# Altium schematic sheet MotherBoard_Interconnect.SchDoc
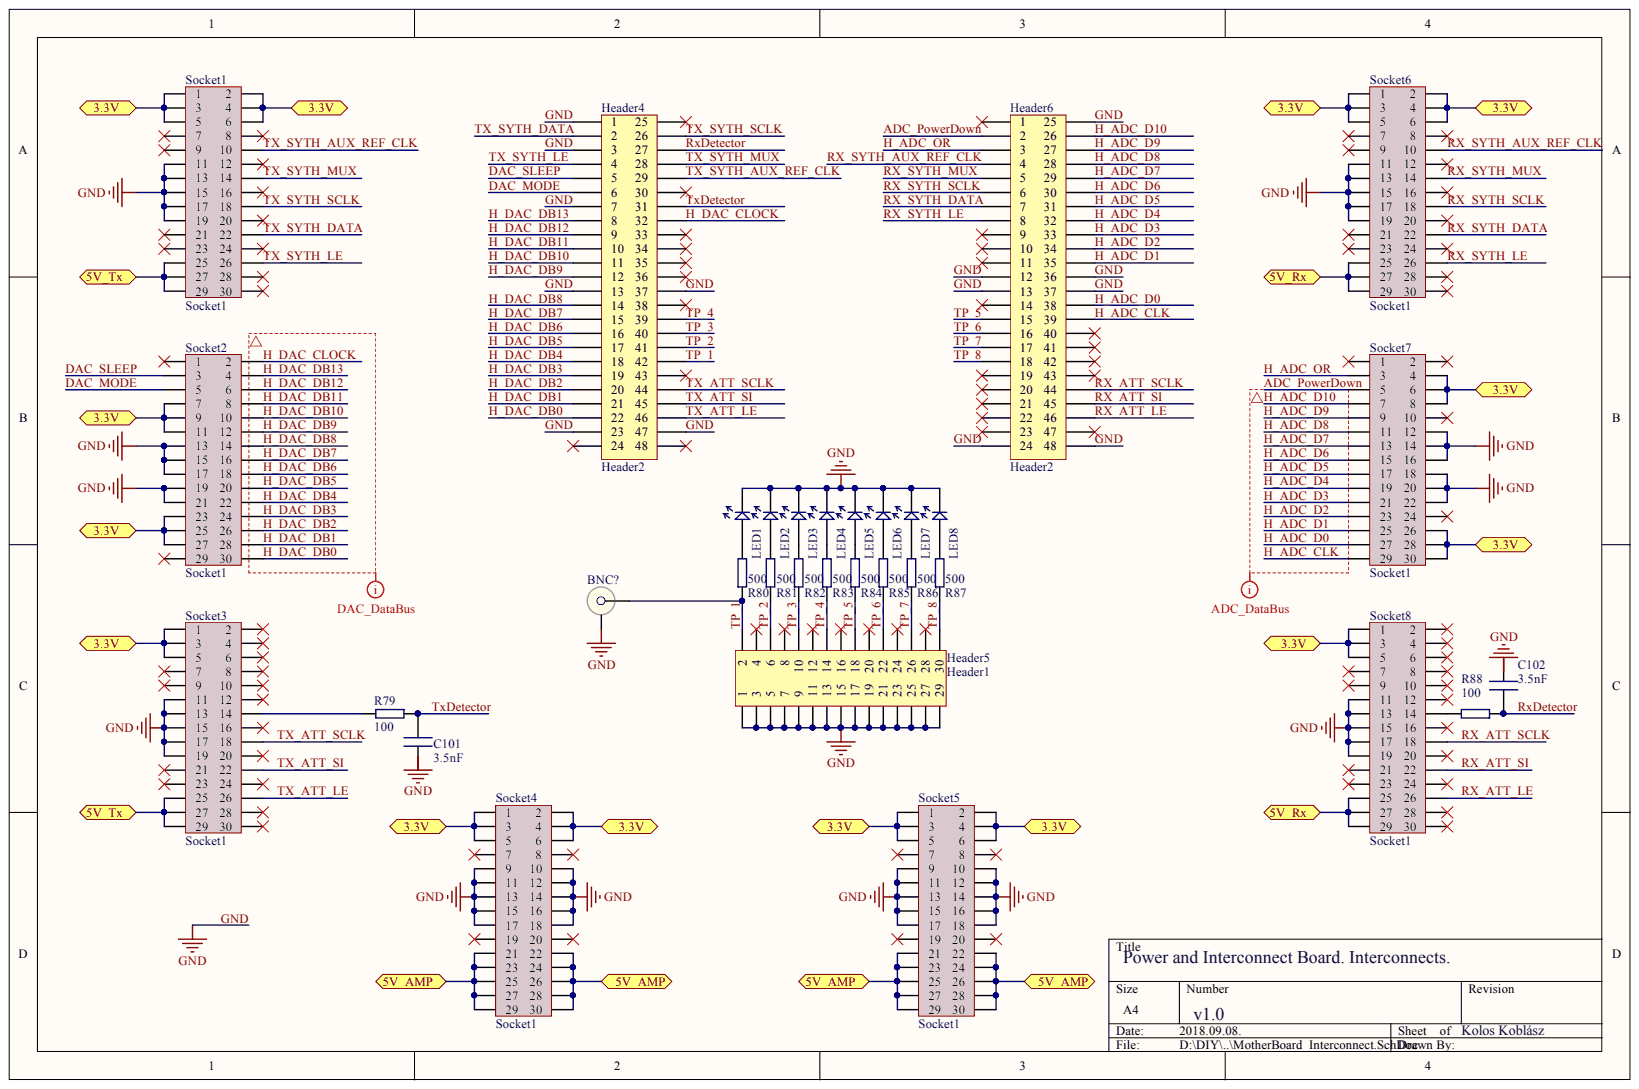
[diagram p0: MotherBoard_Interconnect.SchDoc]

## Parts
BNC?  BNC1  (value not drawn)
C101  value 3.5nF  (hidden comment: Cap1)
C102  value 3.5nF  (hidden comment: Cap1)
Header4  Header2  [Header2]
Header5  Header1  [Header1]
Header6  Header2  [Header2]
LED1  LED_Green  [0805]  (value not drawn)
LED2  LED_Green  [0805]  (value not drawn)
LED3  LED_Green  [0805]  (value not drawn)
LED4  LED_Green  [0805]  (value not drawn)
LED5  LED_Green  [0805]  (value not drawn)
LED6  LED_Green  [0805]  (value not drawn)
LED7  LED_Green  [0805]  (value not drawn)
LED8  LED_Green  [0805]  (value not drawn)
R79  value 100  [SMD]  (hidden comment: Resistor)
R80  value 500  [SMD]  (hidden comment: Resistor)
R81  value 500  [SMD]  (hidden comment: Resistor)
R82  value 500  [SMD]  (hidden comment: Resistor)
R83  value 500  [SMD]  (hidden comment: Resistor)
R84  value 500  [SMD]  (hidden comment: Resistor)
R85  value 500  [SMD]  (hidden comment: Resistor)
R86  value 500  [SMD]  (hidden comment: Resistor)
R87  value 500  [SMD]  (hidden comment: Resistor)
R88  value 100  [SMD]  (hidden comment: Resistor)
Socket1  Socket1  [Socket1]
Socket2  Socket1  [Socket1]
Socket3  Socket1  [Socket1]
Socket4  Socket1  [Socket1]
Socket5  Socket1  [Socket1]
Socket6  Socket1  [Socket1]
Socket7  Socket1  [Socket1]
Socket8  Socket1  [Socket1]

## Ports
3.3V, 5V_AMP, 5V_RX, 5V_TX

## Nets
3.3V: Socket1.1 Socket1.2 Socket1.3 Socket1.4 Socket1.5 Socket1.6 Socket2.7 Socket2.9 Socket2.11 Socket2.23 Socket2.25 Socket2.27 Socket3.1 Socket3.3 Socket3.5 Socket4.1 Socket4.2 Socket4.3 Socket4.4 Socket4.5 Socket4.6 Socket5.1 Socket5.2 Socket5.3 Socket5.4 Socket5.5 Socket5.6 Socket6.1 Socket6.2 Socket6.3 Socket6.4 Socket6.5 Socket6.6 Socket7.4 Socket7.6 Socket7.8 Socket7.26 Socket7.28 Socket7.30 Socket8.1 Socket8.3 Socket8.5 ; port 3.3V
5V_AMP: Socket4.21 Socket4.22 Socket4.23 Socket4.24 Socket4.25 Socket4.26 Socket4.27 Socket4.28 Socket4.29 Socket4.30 Socket5.21 Socket5.22 Socket5.23 Socket5.24 Socket5.25 Socket5.26 Socket5.27 Socket5.28 Socket5.29 Socket5.30 ; port 5V_AMP
ADC_POWERDOWN: Header6.2 Socket7.5
DAC_MODE: Header4.6 Socket2.5
DAC_SLEEP: Header4.5 Socket2.3
GND: BNC?.2 Header4.1 Header4.3 Header4.7 Header4.13 Header4.23 Header4.37 Header4.47 Header5.1 Header5.3 Header5.5 Header5.7 Header5.9 Header5.11 Header5.13 Header5.15 Header5.17 Header5.19 Header5.21 Header5.23 Header5.25 Header5.27 Header5.29 Header6.12 Header6.13 Header6.24 Header6.25 Header6.36 Header6.37 Header6.48 LED1.1 LED2.1 LED3.1 LED4.1 LED5.1 LED6.1 LED7.1 LED8.1 Socket1.11 Socket1.13 Socket1.15 Socket1.17 Socket1.19 Socket2.13 Socket2.15 Socket2.17 Socket2.19 Socket2.21 Socket3.11 Socket3.13 Socket3.15 Socket3.17 Socket3.19 Socket4.9 Socket4.10 Socket4.11 Socket4.12 Socket4.13 Socket4.14 Socket4.15 Socket4.16 Socket4.17 Socket4.18 Socket5.9 Socket5.10 Socket5.11 Socket5.12 Socket5.13 Socket5.14 Socket5.15 Socket5.16 Socket5.17 Socket5.18 Socket6.11 Socket6.13 Socket6.15 Socket6.17 Socket6.19 Socket7.12 Socket7.14 Socket7.16 Socket7.18 Socket7.20 Socket7.22 Socket8.11 Socket8.13 Socket8.15 Socket8.17 Socket8.19
H_ADC_CLK: Header6.39 Socket7.29
H_ADC_D0: Header6.38 Socket7.27
H_ADC_D1: Header6.35 Socket7.25
H_ADC_D2: Header6.34 Socket7.23
H_ADC_D3: Header6.33 Socket7.21
H_ADC_D4: Header6.32 Socket7.19
H_ADC_D5: Header6.31 Socket7.17
H_ADC_D6: Header6.30 Socket7.15
H_ADC_D7: Header6.29 Socket7.13
H_ADC_D8: Header6.28 Socket7.11
H_ADC_D9: Header6.27 Socket7.9
H_ADC_D10: Header6.26 Socket7.7
H_ADC_OR: Header6.3 Socket7.3
H_DAC_CLOCK: Header4.32 Socket2.2
H_DAC_DB0: Header4.22 Socket2.30
H_DAC_DB1: Header4.21 Socket2.28
H_DAC_DB2: Header4.20 Socket2.26
H_DAC_DB3: Header4.19 Socket2.24
H_DAC_DB4: Header4.18 Socket2.22
H_DAC_DB5: Header4.17 Socket2.20
H_DAC_DB6: Header4.16 Socket2.18
H_DAC_DB7: Header4.15 Socket2.16
H_DAC_DB8: Header4.14 Socket2.14
H_DAC_DB9: Header4.12 Socket2.12
H_DAC_DB10: Header4.11 Socket2.10
H_DAC_DB11: Header4.10 Socket2.8
H_DAC_DB12: Header4.9 Socket2.6
H_DAC_DB13: Header4.8 Socket2.4
RX_ATT_LE: Header6.46 Socket8.26
RX_ATT_SCLK: Header6.44 Socket8.18
RX_ATT_SI: Header6.45 Socket8.22
RX_SYTH_AUX_REF_CLK: Header6.4 Socket6.10
RX_SYTH_DATA: Header6.7 Socket6.22
RX_SYTH_LE: Header6.8 Socket6.26
RX_SYTH_MUX: Header6.5 Socket6.14
RX_SYTH_SCLK: Header6.6 Socket6.18
RXDETECTOR: C102.1 Header4.27 R88.1
TP_1: BNC?.1 Header4.42 Header5.2 R80.1
TP_2: Header4.41 Header5.6 R81.1
TP_3: Header4.40 Header5.10 R82.1
TP_4: Header4.39 Header5.14 R83.1
TP_5: Header5.18 Header6.15 R84.1
TP_6: Header5.22 Header6.16 R85.1
TP_7: Header5.26 Header6.17 R86.1
TP_8: Header5.30 Header6.18 R87.1
TX_ATT_LE: Header4.46 Socket3.26
TX_ATT_SCLK: Header4.44 Socket3.18
TX_ATT_SI: Header4.45 Socket3.22
TX_SYTH_AUX_REF_CLK: Header4.29 Socket1.10
TX_SYTH_DATA: Header4.2 Socket1.22
TX_SYTH_LE: Header4.4 Socket1.26
TX_SYTH_MUX: Header4.28 Socket1.14
TX_SYTH_SCLK: Header4.26 Socket1.18
TXDETECTOR: C101.1 Header4.31 R79.1
NetLED1_2: LED1.2 R80.2
NetLED2_2: LED2.2 R81.2
NetLED3_2: LED3.2 R82.2
NetLED4_2: LED4.2 R83.2
NetLED5_2: LED5.2 R84.2
NetLED6_2: LED6.2 R85.2
NetLED7_2: LED7.2 R86.2
NetLED8_2: LED8.2 R87.2
NetR79_2: R79.2 Socket3.14
NetR88_2: R88.2 Socket8.14
NetSocket1_25: Socket1.25 Socket1.27 Socket1.29 Socket3.25 Socket3.27 Socket3.29 ; port 5V_TX
NetSocket6_25: Socket6.25 Socket6.27 Socket6.29 Socket8.25 Socket8.27 Socket8.29 ; port 5V_RX
N00125:
N00126:

Not connected: C101 2; C102 2; Header4 24 25 30 33 34 35 36 38 43 48; Header5 4 8 12 16 20 24 28; Header6 1 9 10 11 14 19 20 21 22 23 40 41 42 43 47; Socket1 7 8 9 12 16 20 21 23 24 28 30; Socket2 1 29; Socket3 2 4 6 7 8 9 10 12 16 20 21 23 24 28 30; Socket4 7 8 19 20; Socket5 7 8 19 20; Socket6 7 8 9 12 16 20 21 23 24 28 30; Socket7 1 2 10 24; Socket8 2 4 6 7 8 9 10 12 16 20 21 23 24 28 30

## Library data of the parts
BNC? = BNC1: BNC Female; Shape: Straight; Component Kind: Standard; Library Name: RadioProjectSchLib.SchLib; Mounting: TroughHole
C101 C102 = Cap1: SMD Capacitor; MaxVolt: 16V; Precision: 1%; Component Kind: Standard; Library Name: RadioProjectSchLib.SchLib
Header4 Header6 = Header2: 48 Pin Header; PinNumber: 48; Pitch: 2.54mm; Row: 2; Component Kind: Standard; Digi Key Order Code: 3M156386-48-ND; Library Name: RadioProjectSchLib.SchLib; Type: 180°
Header5 = Header1: 29 Pin Header; Manufacterer: Samtec; PartNumber: TLW-115-01-G-D-002-RA; PinNumber: 29; Pitch: 2.54mm; Row: 2; Component Kind: Standard; Library Name: RadioProjectSchLib.SchLib; Type: 90°
LED1-LED8 = LED_Green: Diode; Manufacturer: LITEON; Partnumber: LTST-C171KGKT; ForwardCurrent: 20mA; ForwardVoltage: 2V; MaxForwardCurrent: 30mA; MaxRevVoltage: 5V; OrderCode: 160-1426-1-ND; Type: Green; Component Kind: Standard; Library Name: RadioProjectSchLib.SchLib
R79-R88 = Res1: SMD Resistor; MaxPower: 0.1W; Precision: 1%; Component Kind: Standard; Library Name: RadioProjectSchLib.SchLib
Socket1-Socket8 = Socket1: 30 Pin Socket; Manufacterer: Samtec; PartNumber: SLW-115-01-G-D; PinNumber: 30; Pitch: 2.54mm; Row: 2; Component Kind: Standard; Library Name: RadioProjectSchLib.SchLib; Type: 180°
